# Altium schematic sheet ZPlateV2.SchDoc(ZPlateV2)
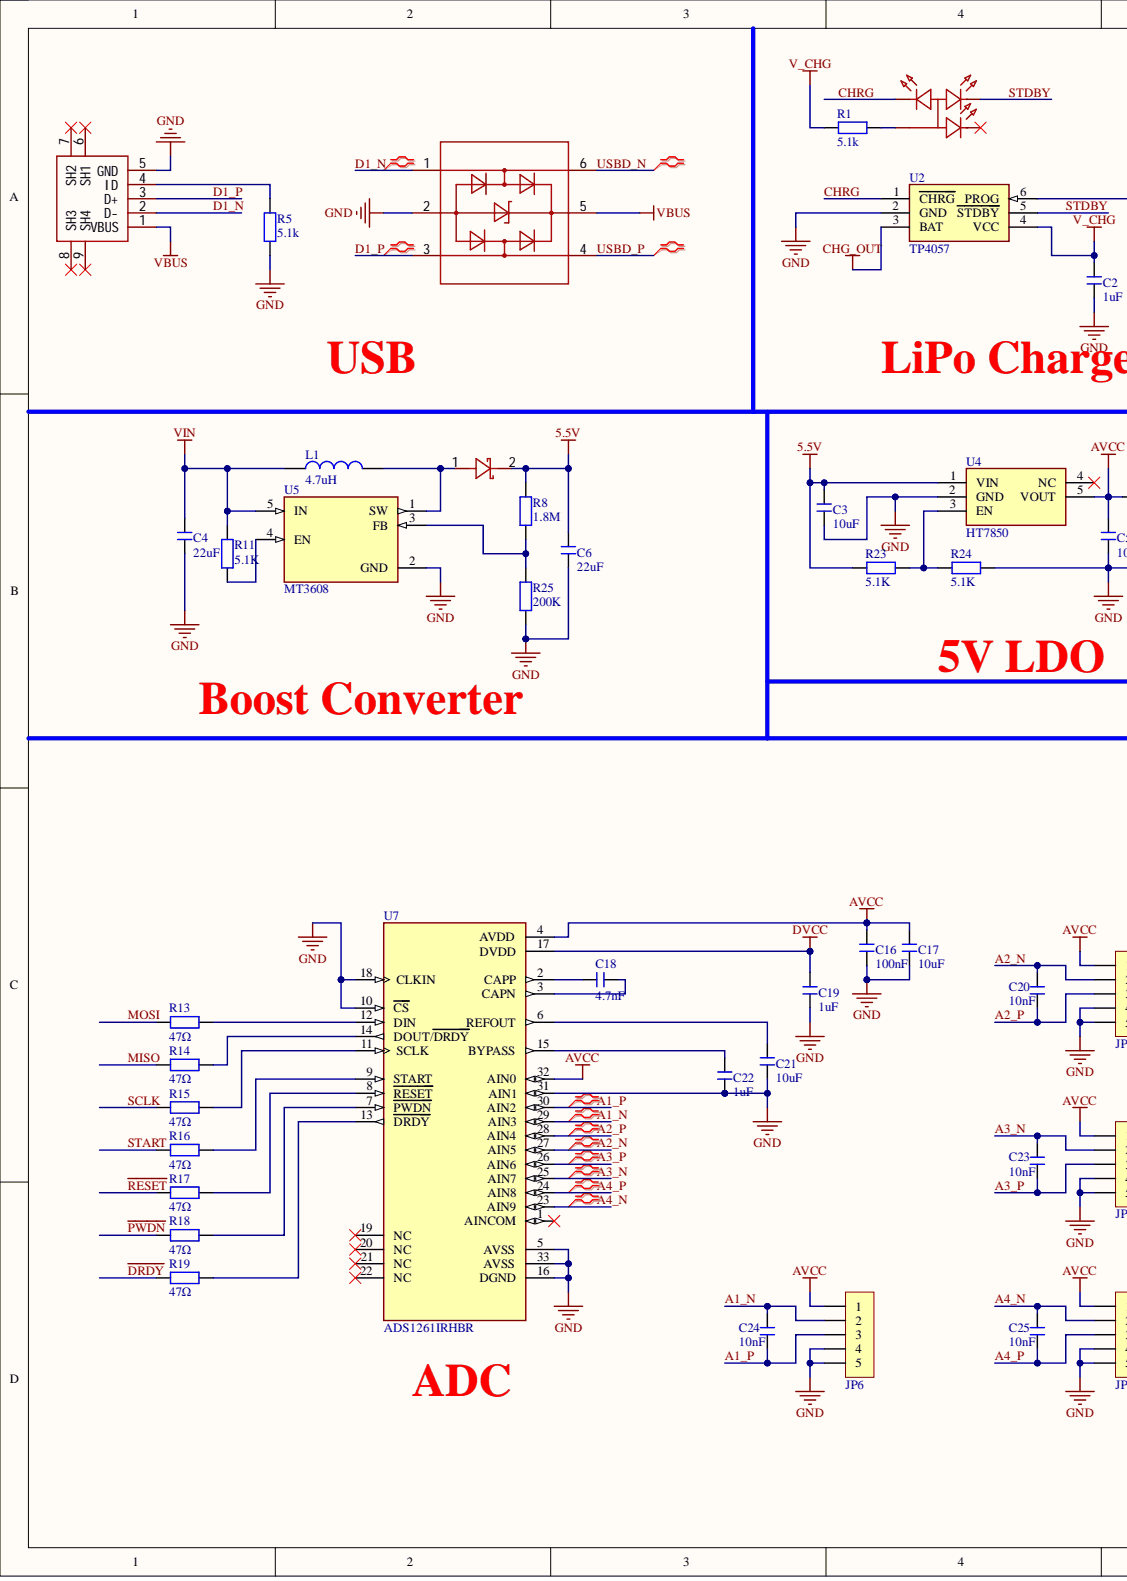
[diagram p0: ZPlateV2.SchDoc, left]
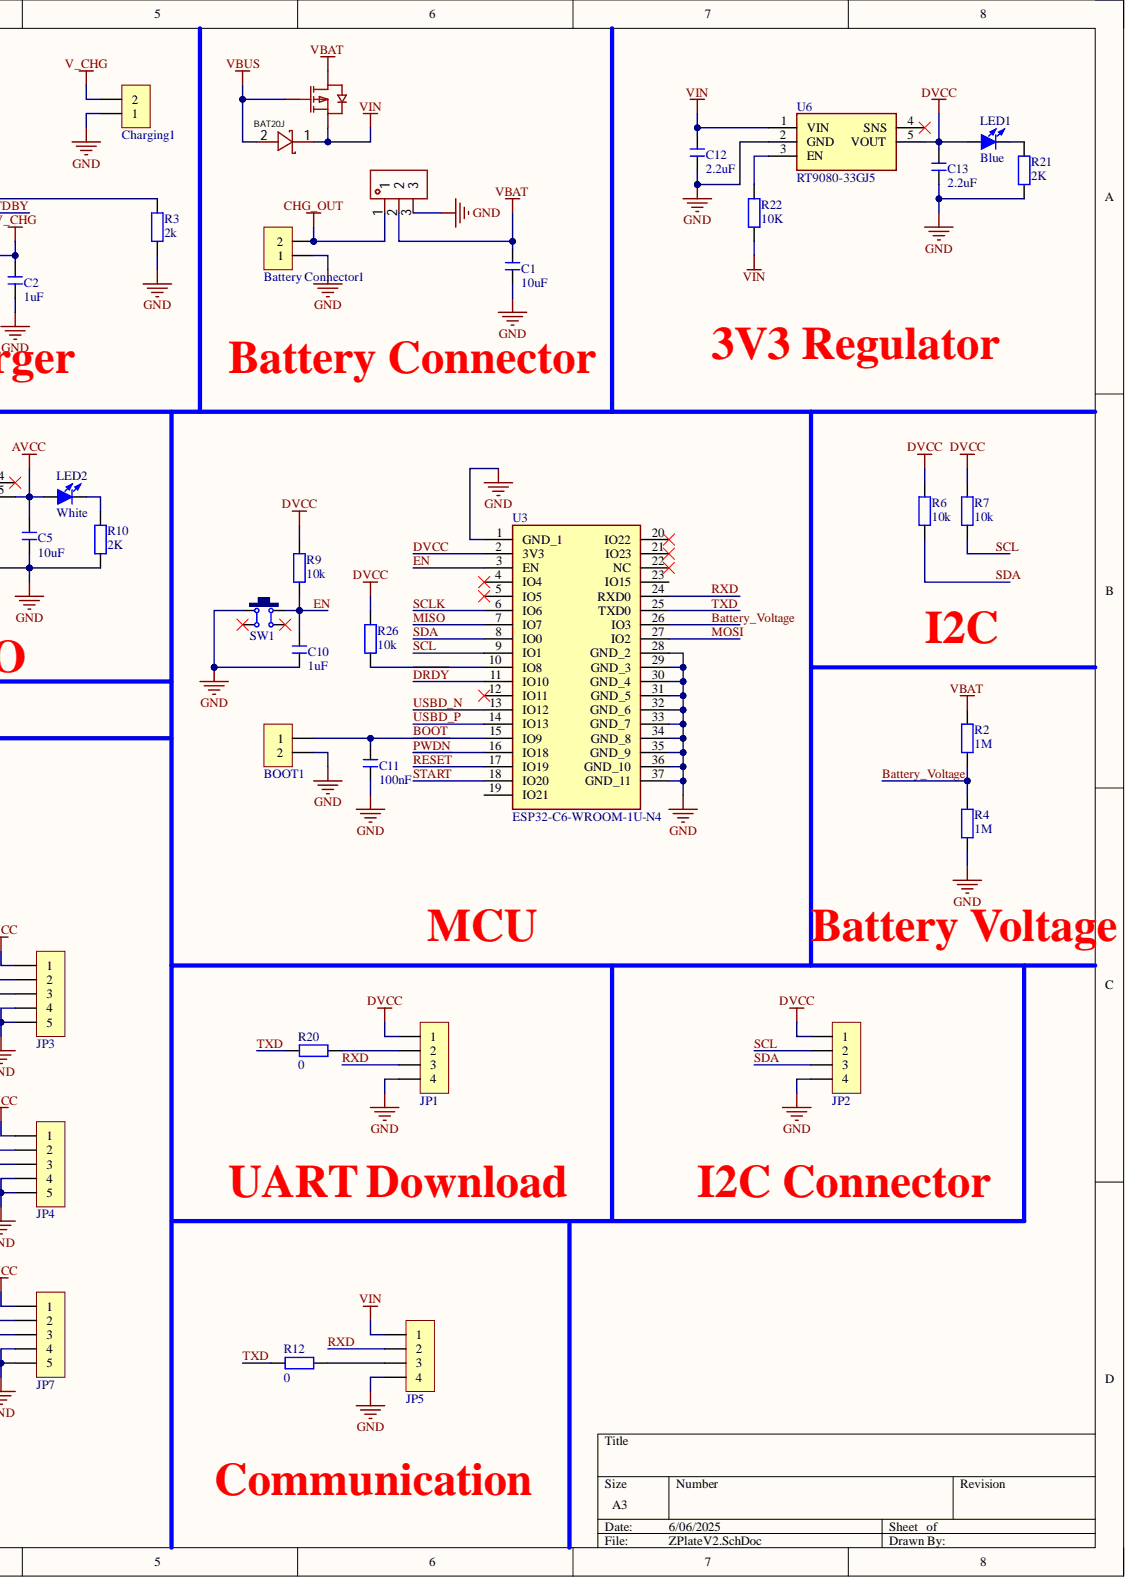
[diagram p1: ZPlateV2.SchDoc, right]

## Parts
Battery_Connector1  HDR-1X2  (value not drawn)
BOOT1  HDR-1X2  (value not drawn)
C1  10uF
C2  1uF
C3  10uF
C4  22uF
C5  10uF
C6  22uF
C10  1uF
C11  100nF
C12  2.2uF
C13  2.2uF
C16  100nF
C17  10uF
C18  4.7nF
C19  1uF
C20  10nF
C21  10uF
C22  1uF
C23  10nF
C24  10nF
C25  10nF
Charging1  HDR-1X2  (value not drawn)
D1  SS54  (value not drawn)
D3  BAT20J
JP1  HDR-1X4  (value not drawn)
JP2  HDR-1X4  (value not drawn)
JP3  HDR-1X5  (value not drawn)
JP4  HDR-1X5  (value not drawn)
JP5  HDR-1X4  (value not drawn)
JP6  HDR-1X5  (value not drawn)
JP7  HDR-1X5  (value not drawn)
L1  4.7uH
LED1  Blue
LED2  White
ON/OFF  DB127S-3.81-3P-GN-S  (value not drawn)
Q1  AO3401A  (value not drawn)
R1  5.1k
R2  1M
R3  2k
R4  1M
R5  5.1k
R6  10k
R7  10k
R8  1.8M
R9  10k
R10  2K
R11  5.1K
R12  0
R13  47Ω
R14  47Ω
R15  47Ω
R16  47Ω
R17  47Ω
R18  47Ω
R19  47Ω
R20  0
R21  2K
R22  10K
R23  5.1K
R24  5.1K
R25  200K
R26  10k
RGB  MHT170RGBCT  (value not drawn)
SW1  6x6x5  (value not drawn)
U1  USBLC6-2SC6  (value not drawn)
U2  TP4057
U3  ESP32-C6-WROOM-1U-N4
U4  HT7850
U5  MT3608
U6  RT9080-33GJ5
U7  ADS1261IRHBR
USB1  MicroXNJ  (value not drawn)

## Nets
5.5V: C3.2 C6.2 D1.2 R8.1 R23.2 U4.1(VIN)
AVCC: C5.2 C16.2 C17.2 JP3.1 JP4.1 JP6.1 JP7.1 LED2.1 U4.5(VOUT) U7.4(AVDD) U7.32(AIN0)
CHG_OUT: Battery_Connector1.2 ON/OFF.1 U2.3(BAT)
GND: Battery_Connector1.1 BOOT1.2 C1.1 C2.1 C3.1 C4.1 C5.1 C6.1 C10.1 C11.1 C12.1 C13.1 C16.1 C17.1 C19.1 C21.1 C22.1 Charging1.1 JP1.4 JP2.4 JP3.4 JP3.5 JP4.4 JP4.5 JP5.4 JP6.4 JP6.5 JP7.4 JP7.5 ON/OFF.3 R3.1 R4.1 R5.2 R10.1 R21.1 R24.1 R25.2 SW1.1 U1.2 U2.2(GND) U3.1(GND_1) U3.28(GND_2) U3.29(GND_3) U3.30(GND_4) U3.31(GND_5) U3.32(GND_6) U3.33(GND_7) U3.34(GND_8) U3.35(GND_9) U3.36(GND_10) U3.37(GND_11) U4.2(GND) U5.2(GND) U6.2(GND) U7.5(AVSS) U7.10(CS) U7.16(DGND) U7.18(CLKIN) U7.31(AIN1) U7.33(AVSS) USB1.5(GND)
V_CHG: C2.2 Charging1.2 R1.2 U2.4(VCC)
VBAT: C1.2 ON/OFF.2 Q1.3 R2.2
VBUS: D3.2 Q1.1 U1.5 USB1.1(VBUS)
VIN: C4.2 C12.2 D3.1 JP5.1 L1.1 Q1.2 R11.1 R22.1 U5.5(IN) U6.1(VIN)
~{DRDY}: R19.2 U3.11(IO10)
A1_N: C24.2 JP6.2 U7.29(AIN3)
A1_P: C24.1 JP6.3 U7.30(AIN2)
A2_N: C20.2 JP3.2 U7.27(AIN5)
A2_P: C20.1 JP3.3 U7.28(AIN4)
A3_N: C23.2 JP4.2 U7.25(AIN7)
A3_P: C23.1 JP4.3 U7.26(AIN6)
A4_N: C25.2 JP7.2 U7.23(AIN9)
A4_P: C25.1 JP7.3 U7.24(AIN8)
Battery_Voltage: R2.1 R4.2 U3.26(IO3)
BOOT: BOOT1.1 C11.2 U3.15(IO9)
CHRG: RGB.1 U2.1(CHRG)
D1_N: U1.1 USB1.2(D-)
D1_P: U1.3 USB1.3(D+)
DVCC: C13.2 C19.2 JP1.1 JP2.1 LED1.1 R6.2 R7.2 R9.2 R26.2 U3.2(3V3) U6.5(VOUT) U7.17(DVDD)
EN: C10.2 R9.1 SW1.2 U3.3(EN)
MISO: R14.2 U3.7(IO7)
MOSI: R13.2 U3.27(IO2)
~{PWDN}: R18.2 U3.16(IO18)
~{RESET}: R17.2 U3.17(IO19)
RXD: JP1.3 JP5.2 U3.24(RXD0)
SCL: JP2.2 R7.1 U3.9(IO1)
SCLK: R15.2 U3.6(IO6)
SDA: JP2.3 R6.1 U3.8(IO0)
START: R16.2 U3.18(IO20)
STDBY: RGB.2 U2.5(STDBY)
TXD: R12.2 R20.2 U3.25(TXD0)
USBD_N: U1.6 U3.13(IO12)
USBD_P: U1.4 U3.14(IO13)
NetC18_1: C18.1 U7.2(CAPP)
NetC18_2: C18.2 U7.3(CAPN)
NetC21_2: C21.2 U7.6(REFOUT)
NetC22_2: C22.2 U7.15(BYPASS)
NetD1_1: D1.1 L1.2 U5.1(SW)
NetJP1_2: JP1.2 R20.1
NetJP5_3: JP5.3 R12.1
NetLED1_2: LED1.2 R21.2
NetLED2_2: LED2.2 R10.2
NetR1_1: R1.1 RGB.4
NetR3_2: R3.2 U2.6(PROG)
NetR5_1: R5.1 USB1.4(ID)
NetR8_2: R8.2 R25.1 U5.3(FB)
NetR11_2: R11.2 U5.4(EN)
NetR13_1: R13.1 U7.12(DIN)
NetR14_1: R14.1 U7.14(DOUT/DRDY)
NetR15_1: R15.1 U7.11(SCLK)
NetR16_1: R16.1 U7.9(START)
NetR17_1: R17.1 U7.8(RESET)
NetR18_1: R18.1 U7.7(PWDN)
NetR19_1: R19.1 U7.13(DRDY)
NetR22_2: R22.2 U6.3(EN)
NetR23_1: R23.1 R24.2 U4.3(EN)
NetR26_1: R26.1 U3.10(IO8)

Not connected: RGB 3; SW1 3 4; U3 4(IO4) 5(IO5) 12(IO11) 19(IO21) 20(IO22) 21(IO23) 22(NC) 23(IO15); U4 4(NC); U6 4(SNS); U7 1(AINCOM) 19(NC) 20(NC) 21(NC) 22(NC); USB1 6(SH1) 7(SH2) 8(SH3) 9(SH4)

## Library data of the parts
Battery_Connector1 = Header 2: 2P接插件; BOM名称: 2P接插件; Component Kind: Standard; Library Name: 5.3 - 接插件-脚距2.0.SchLib; 技术支持，联系售后: https://item.taobao.com/item.htm?id=566775463719
BOOT1 = Header 2: 2P接插件; 版权归属: 封装库来自淘宝 ID：黄晶宝石; BOM名称: 2P接插件; Component Kind: Standard; Library Name: 5.4 - 接插件-脚距2.54 (100mil) .SchLib
C1 C11 C16 C17 C19 C21 C22 = C: 贴片电容, X7R; BOM名称: 无极性贴片电容; 淘宝ID: 封装库来自淘宝 ID：黄晶宝石; Component Kind: Standard; Library Name: 0.1 - 电阻-电容-电感.SchLib; 唯一出售店铺网址: https://shop463601444.taobao.com; 联系售后，技术支持: https://shop463601444.taobao.com
C2 C10 = C: 贴片电容，X7R; Component Kind: Standard; Library Name: 0.1 - 电阻-电容-电感.SchLib
C3-C6 C12 C13 = C: 贴片电容; Component Kind: Standard; Library Name: ZazSports.SchLib
C18 C20 C23-C25 = C: 贴片电容, C0G; BOM名称: 无极性贴片电容; 淘宝ID: 封装库来自淘宝 ID：黄晶宝石; Component Kind: Standard; Library Name: 0.1 - 电阻-电容-电感.SchLib; 唯一出售店铺网址: https://shop463601444.taobao.com; 联系售后，技术支持: https://shop463601444.taobao.com
Charging1 = Header 2: Charging Port; BOM名称: 2P接插件; 版权归属: 封装库来自淘宝 ID：黄晶宝石; Component Kind: Standard; Library Name: 5.4 - 接插件-脚距2.54 (100mil) .SchLib
D1 = SS54: 肖特基二极管，整流电流 5A，正向压降550mV，反向耐压40V  商品编号C7420369; Manufacturer: 宏迦橙; Add into BOM: yes; Device: SS54_C7420369; Diode Configuration: 独立式; Forward Voltage (Vf@If): 550mV@5.0A; Rectified Current: 5A; Reverse Leakage Current (Ir): 50uA@40V; Reverse Voltage (Vr): 40V; Component Kind: Standard; Convert to PCB: yes; Datasheet: https://atta.szlcsc.com/upload/public/pdf/source/20230828/254C3882F8647358AD9F60DD73611B5E.pdf; JLCPCB Part Class: Extended Part; LCSC Part Name: 电压:40V 电流:5A; Manufacturer Part: SS54; Supplier: LCSC; Supplier Footprint: SMA(DO-214AC); Supplier Part: C7420369; Symbol: SS54_C7420369; Library Name: ZazSports.SchLib
D3 = 1N5819WS_C191023: 肖特基二极管，BAT20J; Manufacturer: Hottech(合科泰); Add into BOM: yes; Forward Voltage (Vf@If): 600mV@1A; Rectified Current: 1A; Reverse Voltage (Vr): 40V; Component Kind: Standard; Convert to PCB: yes; Datasheet: https://atta.szlcsc.com/upload/public/pdf/source/20220428/3CB86FD8D28D653594B4E35F9D5AEFD9.pdf; Device: 1N5819WS_C191023; JLCPCB Part Class: Basic Part; LCSC Part Name: 电压:40V 电流:1A; Library Name: 1N5819WS1.SCHLIB; Manufacturer Part: 1N5819WS; Supplier: LCSC; Supplier Footprint: SOD-323; Supplier Part: C191023; Symbol: 1N5819WS
JP1 JP2 JP5 = Header 4: 4P接插件; 学习资源: 最新版本发布https://item.taobao.com/item.htm?id=566775463719; 版权归属: 封装库来自淘宝 ID：黄晶宝石; BOM名称: 4P接插件; Component Kind: Standard; Library Name: 5.4 - 接插件-脚距2.54 (100mil) .SchLib
JP3 JP4 JP6 JP7 = Header 5: 5P接插件; 封装库来自淘宝卖家 ID：黄晶宝石: 最新版本发布https://item.taobao.com/item.htm?id=566775463719; Component Kind: Standard; Library Name: 5.3 - 接插件-脚距2.0.SchLib
L1 = L: 小功率贴片电感; Component Kind: Standard; Library Name: ZazSports.SchLib
LED1 LED2 = LED-SMD: 贴片LED; Component Kind: Standard; Library Name: ZazSports.SchLib
ON/OFF = DB127S-3.81-3P-GN-S: Manufacturer: DORABO(地博电气); Add into BOM: yes; Component Kind: Standard; Convert to PCB: yes; Datasheet: https://atta.szlcsc.com/upload/public/pdf/source/20230321/B99F84F1F7FFFF61C42D18BA6B557A3D.pdf; Device: DB127S-3.81-3P-GN-S; JLCPCB Part Class: Extended Part; LCSC Part Name: DB127S-3.81-3P-GN; Library Name: DB127S-3.81-3P-GN.SCHLIB; Manufacturer Part: DB127S-3.81-3P-GN-S; Supplier: LCSC; Supplier Footprint: P=3.81mm; Supplier Part: C2997515; Symbol: DB127S-3.81-3P-GN
Q1 = AO3401A_C15127: Manufacturer: AOS; Add into BOM: yes; Continuous Drain Current (Id): 4A; Drain Source On Resistance (RDS(on)@Vgs,Id): 44mΩ@10V,4.3A; Drain Source Voltage (Vdss): 30V; Gate Threshold Voltage (Vgs(th)@Id): 1.3V@250uA; Input Capacitance (Ciss@Vds): 1.2nF@15V; Power Dissipation (Pd): 1.4W; Total Gate Charge (Qg@Vgs): 12.2nC@4.5V; Type: 1个P沟道; Component Kind: Standard; Convert to PCB: yes; Datasheet: https://atta.szlcsc.com/upload/public/pdf/source/20160507/1462613609053.pdf; Device: AO3401A_C15127; JLCPCB Part Class: Basic Part; LCSC Part Name: 1个P沟道 耐压:30V 电流:4A; Library Name: AO3401A1.SCHLIB; Manufacturer Part: AO3401A; Operating Temperature: -55℃~+150℃@(Tj); Supplier: LCSC; Supplier Footprint: SOT-23; Supplier Part: C15127; Symbol: AO3401A
R1-R12 R20-R26 = R: 贴片电阻; Component Kind: Standard
  R1-R7 R9 R12 R20 R26: Library Name: 0.1 - 电阻-电容-电感.SchLib
  R8 R10 R11 R21-R25: Library Name: ZazSports.SchLib
R13-R19 = R: 贴片电阻; 封装库来自淘宝卖家 ID：黄晶宝石: 其他店铺购入均为盗版，不享受售后技术支持服务，请立即申请退款; 版权归属: 来自淘宝 ID：黄晶宝石; BOM名称: 贴片电阻; Component Kind: Standard; Library Name: 0.1 - 电阻-电容-电感.SchLib; 联系售后: https://item.taobao.com/item.htm?id=566775463719
RGB = MHT170RGBCT: 商品编号  C2962094， 封装0805; Manufacturer: MEIHUA(美华); Add into BOM: yes; Component Kind: Standard; Convert to PCB: yes; Datasheet: https://atta.szlcsc.com/upload/public/pdf/source/20220927/6D57F97E922E7DED71E17ABE090AED59.pdf; Device: MHT170RGBCT; JLCPCB Part Class: Extended Part; LCSC Part Name: RGB三色 正贴; Manufacturer Part: MHT170RGBCT; Supplier: LCSC; Supplier Footprint: 0805; Supplier Part: C2962094; Symbol: MHT170RGBCT; Library Name: ZazSports.SchLib
SW1 = TSW SMD-6x6: 贴片6x6轻触开关; 版权归属: 封装库来自淘宝 ID：黄晶宝石; BOM名称: 贴片6x6轻触开关; Component Kind: Standard; Library Name: 0.7 - 常用按键-开关.SchLib; 唯一出售店铺网址: https://shop463601444.taobao.com
U1 = USBLC6-2SC6: Manufacturer: ST(意法半导体); Add into BOM: yes; Breakdown Voltage: 6V; Device: USBLC6-2SC6_C7519; Maximum Clamping Voltage: 17V; Number of Lines: 双路; Peak Pulse Current (Ipp)@10/1000us: 5A; polarity: 单向; Reverse Stand-Off Voltage (Vrwm): 5.25V; Component Kind: Standard; Convert to PCB: yes; Datasheet: https://atta.szlcsc.com/upload/public/pdf/source/20140724/1457707160627.pdf; JLCPCB Part Class: Extended Part; LCSC Part Name: 单向ESD; Manufacturer Part: USBLC6-2SC6; Operating Temperature: -40℃~+125℃@(Tj); Supplier: LCSC; Supplier Footprint: SOT-23-6; Supplier Part: C7519; Symbol: USBLC6-2SC6; Type: ESD; Library Name: ZazSports.SchLib
U2 = TP4057: SOT23-6 BATTERY MANAGEMENT ICS R; MF: UMW; Check_prices: https://www.snapeda.com/parts/TP4057/Umwelt+Sensor+Teknik/view-part/?ref=eda; Component Kind: Standard; Library Name: TP4057.IntLib; MP: TP4057; Package: None; SnapEDA_Link: https://www.snapeda.com/parts/TP4057/Umwelt+Sensor+Teknik/view-part/?ref=snap
U3 = ESP32-C6-WROOM-1U-N4: Multiprotocol Modules ESP32-C6-WROOM-1U is a ESP32-C6 based module,  Wi-Fi 6 in 2.4 GHz band, Bluetooth 5, Zigbee 3.0 and Thread.; Manufacturer_Name: Espressif Systems; Geometry.Height: 3.35mm; Component Kind: Standard; Datasheet Link: https://www.espressif.com/sites/default/files/documentation/esp32-c6-wroom-1_wroom-1u_datasheet_en.pdf; Library Name: ESP32-C6-WROOM-1U-N4.SchLib; Manufacturer_Part_Number: ESP32-C6-WROOM-1U-N4; Mouser Part Number: 356-ESP32C6WROOM1UN4; Mouser Price/Stock: https://www.mouser.co.uk/ProductDetail/Espressif-Systems/ESP32-C6-WROOM-1U-N4?qs=Imq1NPwxi74mh1MmrGnEKA%3D%3D
U4 = HT7850: Linear Voltage Regulator IC Positive Fixed, Low voltage drop: 360mV  Output 500mA SOT-23-5; MF: Richtek USA; MP: RT9080-33GJ5; Check_prices: https://www.snapeda.com/parts/RT9080-33GJ5/Richtek+USA+Inc./view-part/?ref=eda; Component Kind: Standard; Package: TSOT-23 Richtek; SnapEDA_Link: https://www.snapeda.com/parts/RT9080-33GJ5/Richtek+USA+Inc./view-part/?ref=snap; Library Name: ZazSports.SchLib
U5 = MT3608: High Efficiency 1.2MHz2A Step Up Converter; MF: Aerosemi Technology Co., Ltd; Availability: Not in stock; Check_prices: https://www.snapeda.com/parts/MT3608/Aerosemi+Technology+Co.%252C+Ltd/view-part/?ref=eda; Component Kind: Standard; MP: MT3608; Package: Package; Price: None; SnapEDA_Link: https://www.snapeda.com/parts/MT3608/Aerosemi+Technology+Co.%252C+Ltd/view-part/?ref=snap; Library Name: ZazSports.SchLib
U6 = RT9080-33GJ5: Linear Voltage Regulator IC Positive Fixed 1 Output 600mA TSOT-23-5; MF: Richtek USA; Check_prices: https://www.snapeda.com/parts/RT9080-33GJ5/Richtek+USA+Inc./view-part/?ref=eda; Component Kind: Standard; MP: RT9080-33GJ5; Package: TSOT-23 Richtek; SnapEDA_Link: https://www.snapeda.com/parts/RT9080-33GJ5/Richtek+USA+Inc./view-part/?ref=snap; Library Name: ZazSports.SchLib
U7 = ADS1261IRHBR: 24-bit, 40-kSPS, 10-ch delta-sigma ADC with PGA, VREF, IDACs & AC excitation for factory automation; MF: Texas Instruments; Check_prices: https://www.snapeda.com/parts/ADS1261IRHBR/Texas+Instruments/view-part/?ref=eda; Component Kind: Standard; Library Name: ADS1261IRHBR.IntLib; MP: ADS1261IRHBR; Package: VQFN-32-32 Texas Instruments; SnapEDA_Link: https://www.snapeda.com/parts/ADS1261IRHBR/Texas+Instruments/view-part/?ref=snap
USB1 = MicroXNJ: Manufacturer: SHOU HAN(首韩); Add into BOM: yes; Connect-Disconnect Life: 5千次; Connector Type: Micro-B; Core Colour: 黑色; Current Rating - Power (Max): 1.8A; Gender: 母; Number of Contacts: 5P; Number of Ports: 1; Rated Voltage (Max): 30V; Component Kind: Standard; Convert to PCB: yes; Datasheet: https://atta.szlcsc.com/upload/public/pdf/source/20220415/2494EDFF499AF6F852F7C820374B6AF3.pdf; Device: MicroXNJ; JLCPCB Part Class: Extended Part; LCSC Part Name: Micro-B 母 卧贴; Library Name: MICROXNJ1_MICROXNJ.SCHLIB; Manufacturer Part: MicroXNJ; Mounting Style: 卧贴; Operating Temperature Range: -20℃~+85℃; Standard: USB 2.0; Supplier: LCSC; Supplier Footprint: SMD; Supplier Part: C404969; Symbol: MICROXNJ
